# Altium schematic sheet ethernet.SchDoc(ethernet)
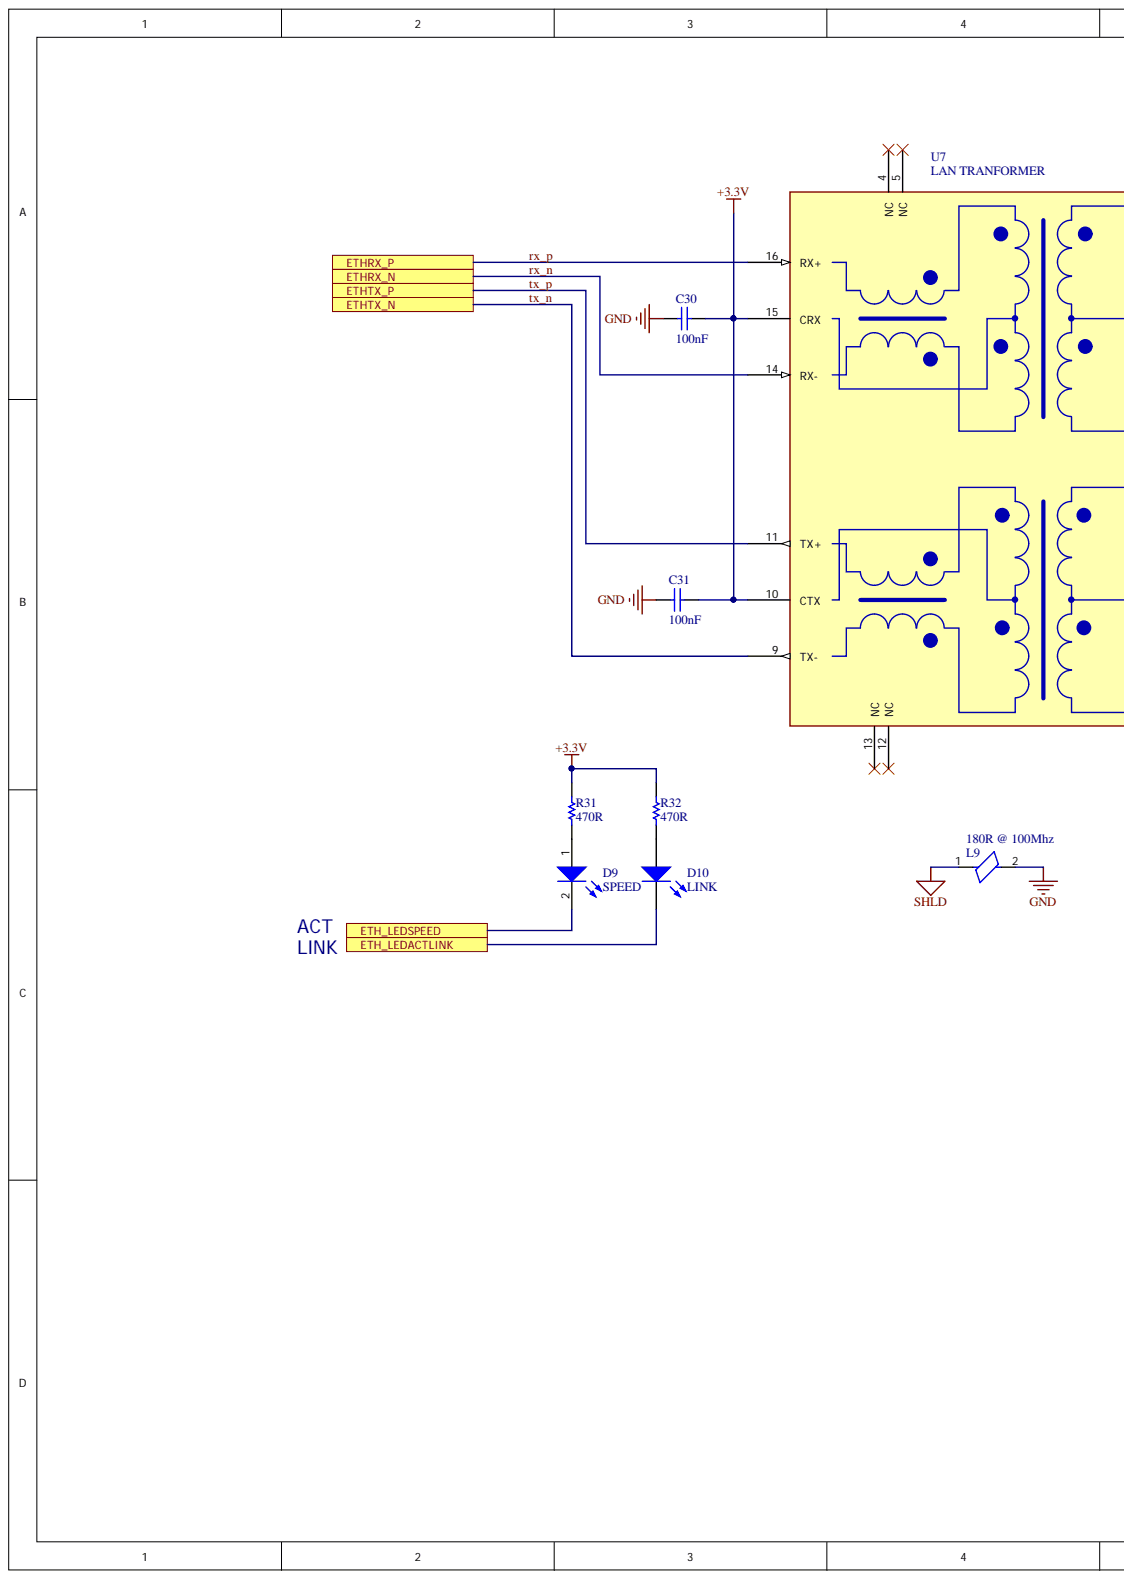
[diagram p0: ethernet.SchDoc, left]
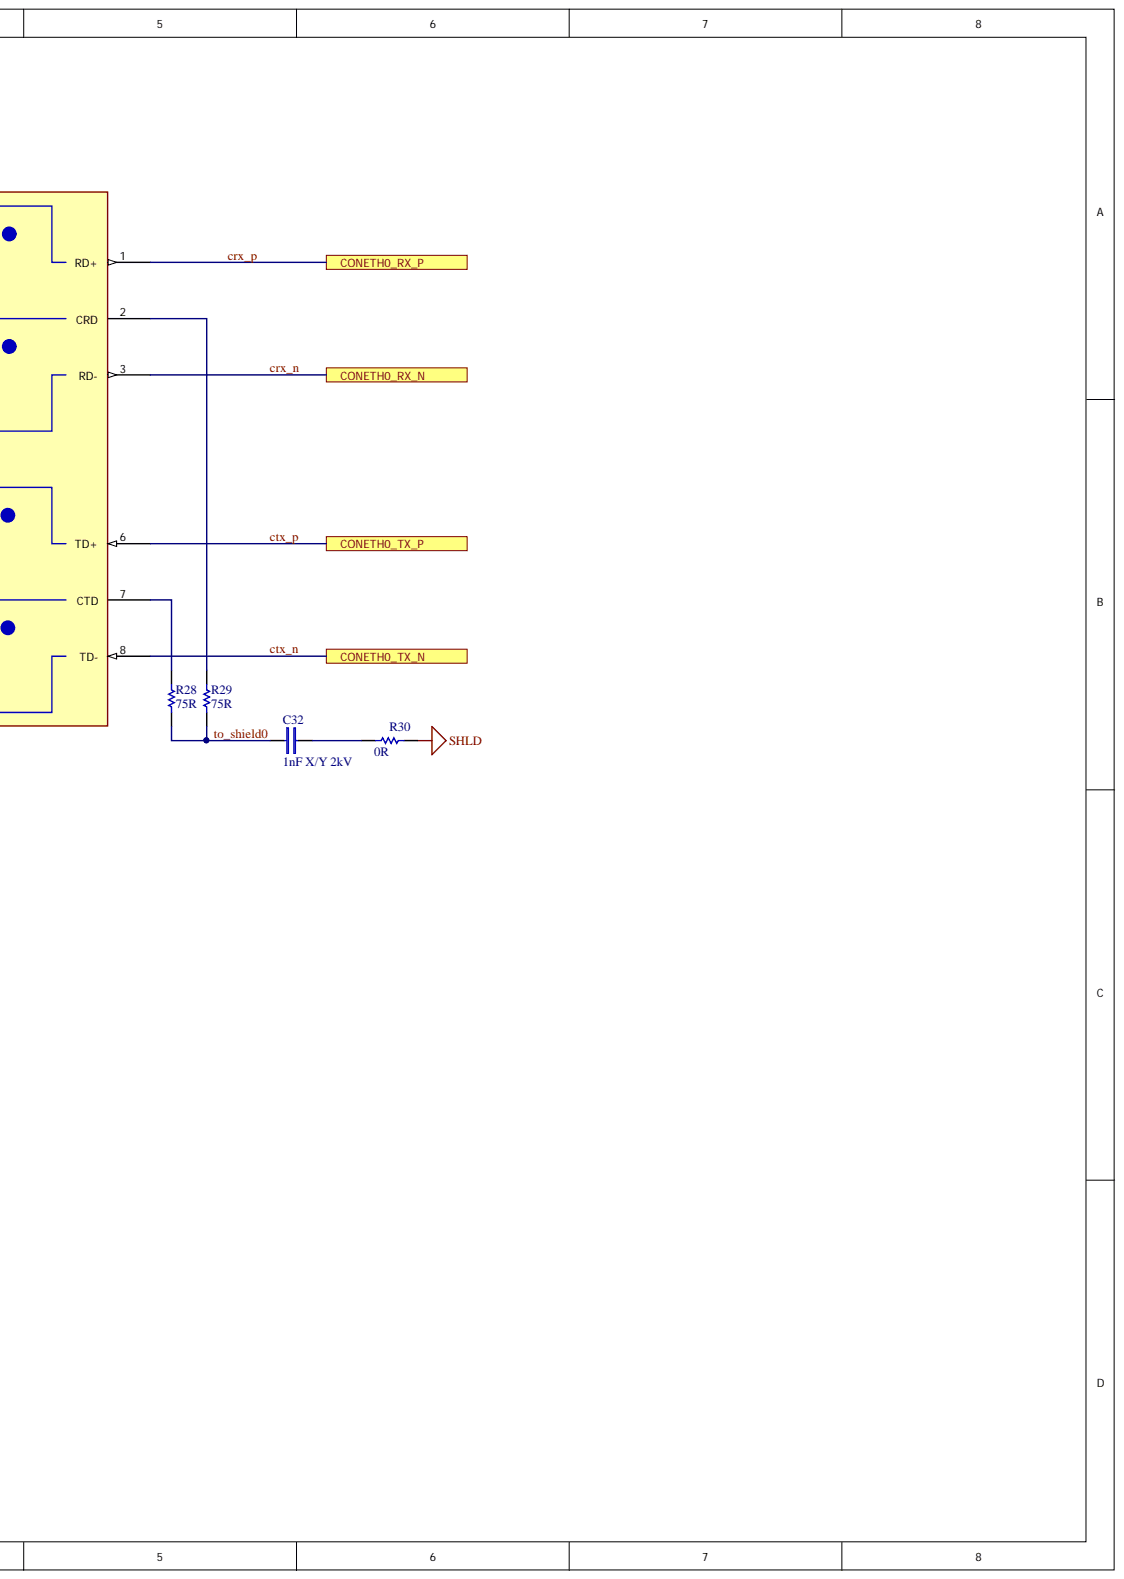
[diagram p1: ethernet.SchDoc, right]

## Parts
C30  100nF  [0603_[1608]]
C31  100nF  [0603_[1608]]
C32  1nF X/Y 2kV  [1808_[4520]]
D9  SPEED  [0603_[1608]_K2-A1_LED]
D10  LINK  [0603_[1608]_K2-A1_LED]
L9  180R @ 100Mhz  [0603_[1608]]
R28  75R  [0603_[1608]]
R29  75R  [0603_[1608]]
R30  0R  [0603_[1608]]
R31  470R  [0603_[1608]]
R32  470R  [0603_[1608]]
U7  LAN TRANFORMER  [LAN_TR_1.27MM_SMD]

## Ports
CONETH0_RX_N, CONETH0_RX_P, CONETH0_TX_N, CONETH0_TX_P, ETH_LEDACTLINK, ETH_LEDSPEED, ETHRX_N, ETHRX_P, ETHTX_N, ETHTX_P

## Nets
+3.3V: C30.2 C31.2 R31.2 R32.2 U7.10(CTX) U7.15(CRX)
GND: C30.1 C31.1 L9.2
SHLD: L9.1 R30.1
crx_n: U7.3(RD-) ; port CONETH0_RX_N
crx_p: U7.1(RD+) ; port CONETH0_RX_P
ctx_n: U7.8(TD-) ; port CONETH0_TX_N
ctx_p: U7.6(TD+) ; port CONETH0_TX_P
ETH_LEDACTLINK: D10.2 ; port ETH_LEDACTLINK
ETH_LEDSPEED: D9.2 ; port ETH_LEDSPEED
rx_n: U7.14(RX-) ; port ETHRX_N
rx_p: U7.16(RX+) ; port ETHRX_P
to_shield0: C32.1 R28.1 R29.1
tx_n: U7.9(TX-) ; port ETHTX_N
tx_p: U7.11(TX+) ; port ETHTX_P
NetC32_2: C32.2 R30.2
NetD9_1: D9.1 R31.1
NetD10_1: D10.1 R32.1
NetR28_2: R28.2 U7.7(CTD)
NetR29_2: R29.2 U7.2(CRD)

Not connected: U7 4(NC) 5(NC) 12(NC) 13(NC)

## Library data of the parts
C30 C31 = Cap: Ceramic X7R 50V; Value: 100pF; Simulation: CAP; Signal Integrity: Cap; Published: 8-Jun-2000; PackageReference: RAD-0.3; PackageDescription: Radial Cap, Thru-Hole; 2 Leads; 0.3 in Pin Spacing; LatestRevisionNote: Re-released for DXP Platform.; LatestRevisionDate: 17-Jul-2002; Component Kind: Standard; Publisher: Altium Limited; Library Name: DEVELER_Library.SchLib
C32 = cap_xy: CER 250VAC X7R X1/Y1 (GA342DR7GF102KW); Component Kind: Standard; Library Name: DEVELER_Library.SchLib
D9 D10 = LED0: LED Green; Simulation: LED0; Published: 8-Jun-2000; PackageReference: LED-0; PackageDescription: LED; 2 Leads; LatestRevisionNote: Mech Layer 1 removed.; LatestRevisionDate: 08-Jul-2005; Component Kind: Standard; Publisher: Altium Limited; Library Name: DEVELER_Library.SchLib
L9 = BEAD_ FERRITE: Ferrite Beat (WE 7427927218); Library Name: project42_lib.SchLib; Component Kind: Standard
R28 R29 = Res1: Resistor; Simulation: RESISTOR; Signal Integrity: Res; Revision: July-2002: Re-released for DXP Platform.; Component Kind: Standard; Library Name: DEVELER_Library.SchLib
R30 = Res1: Resistor; Value: 0; Simulation: RESISTOR; Signal Integrity: Res; Published: 8-Jun-2000; PackageReference: AXIAL-0.3; PackageDescription: Axial Device, Thru-Hole; 2 Leads; 0.3 in Pin Spacing; LatestRevisionNote: Re-released for DXP Platform.; LatestRevisionDate: 17-Jul-2002; Component Kind: Standard; Publisher: Altium Limited; Library Name: DEVELER_Library.SchLib
R31 R32 = Res1: Resistor; Value: 1K; Simulation: RESISTOR; Signal Integrity: Res; Revision: July-2002: Re-released for DXP Platform.; Published: 8-Jun-2000; Component Kind: Standard; Publisher: Altium Limited; Library Name: DEVELER_Library.SchLib
U7 = LAN-TR-WE7490100111A: Lan transformer (WE 7490100111A (side A); WE 7490100161A (side B)); Component Kind: Standard; Library Name: DEVELER_Library.SchLib
